# Altium schematic sheet MCU - MKL05VQFN24.SchDoc("MCU - MKL05VQFN24")
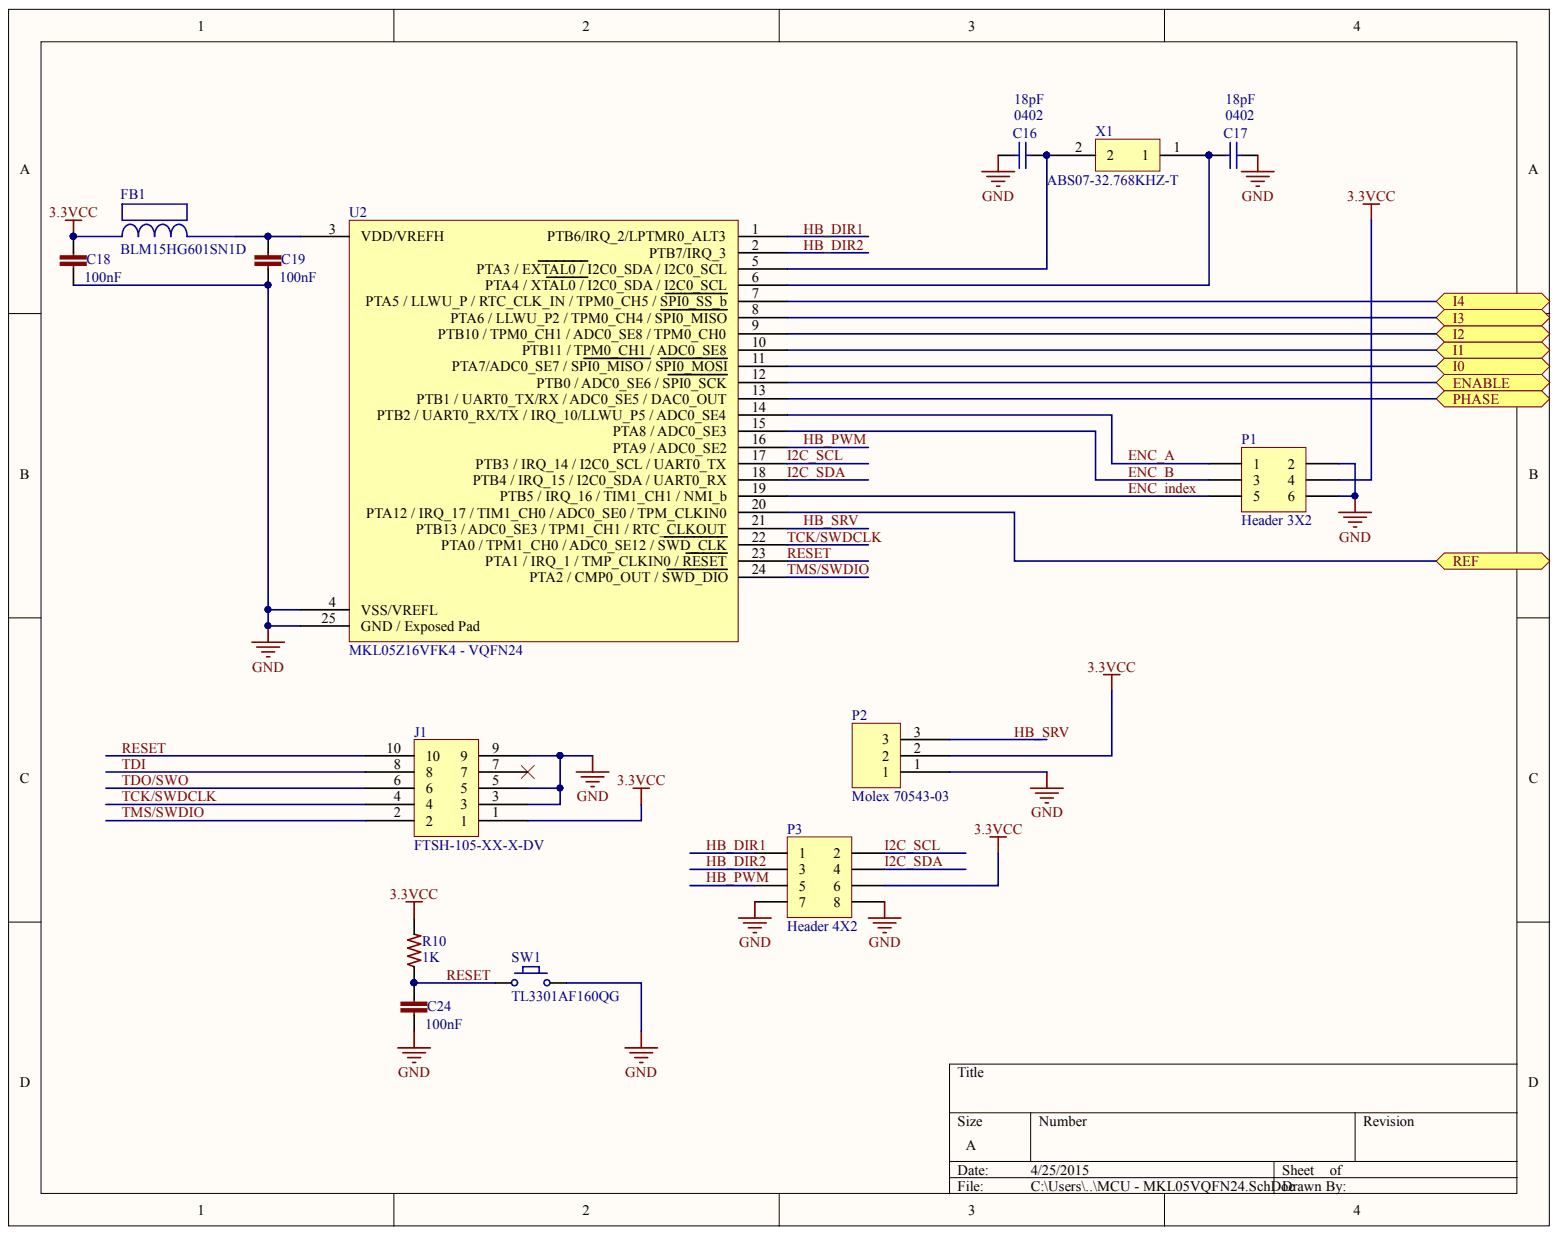
[diagram p0: MCU - MKL05VQFN24.SchDoc]

## Parts
C16  0402  value 18pF  [C0402]
C17  0402  value 18pF  [C0402]
C18  100nF  [C0402]
C19  100nF  [C0402]
C24  100nF  [C0402]
FB1  BLM15HG601SN1D  [BLM18PG121SN1D]
J1  FTSH-105-XX-X-DV  [FTSH-105-XX-X-DV]
P1  Header 3X2  [HDR2X3 Shrouded - Molex 0901301106]
P2  Molex 70543-03  [70543-03]
P3  Header 4X2  [HDR2X4 Shrouded]
R10  1K  [R0603]
SW1  TL3301AF160QG  [TL3301AF160QG]
U2  MKL05Z16VFK4 - VQFN24  [98ASA00474D]
X1  ABS07-32.768KHZ-T  [ABS07-32.768KHZ-T]

## Ports
ENABLE, I0, I1, I2, I3, I4, PHASE, REF

## Nets
3.3VCC: C18.1 FB1.1 J1.1 P1.4 P2.2 P3.6 R10.2
GND: C16.2 C17.1 C18.2 C19.2 C24.2 J1.3 J1.5 J1.9 P1.2 P1.6 P2.1 P3.7 P3.8 SW1.2 U2.4(VSS/VREFL) U2.25(GND_/_Exposed_Pad)
ENABLE: U2.12(PTB0_/_ADC0_SE6_/_SPI0_SCK) ; port ENABLE
ENC_A: P1.1 U2.14(PTB2_/_UART0_RX/TX_/_IRQ_10/LLWU_P5_/_ADC0_SE4)
ENC_B: P1.3 U2.15(PTA8_/_ADC0_SE3)
ENC_INDEX: P1.5 U2.19(PTB5_/_IRQ_16_/_TIM1_CH1_/_NMI_b)
HB_DIR1: P3.1 U2.1(PTB6/IRQ_2/LPTMR0_ALT3)
HB_DIR2: P3.3 U2.2(PTB7/IRQ_3)
HB_PWM: P3.5 U2.16(PTA9_/_ADC0_SE2)
HB_SRV: P2.3 U2.21(PTB13_/_ADC0_SE3_/_TPM1_CH1_/_RTC_CLKOUT)
I0: U2.11(PTA7/ADC0_SE7_/_SPI0_MISO_/_SPI0_MOSI) ; port I0
I1: U2.10(PTB11_/_TPM0_CH1_/_ADC0_SE8) ; port I1
I2: U2.9(PTB10_/_TPM0_CH1_/_ADC0_SE8_/_TPM0_CH0) ; port I2
I2C_SCL: P3.2 U2.17(PTB3_/_IRQ_14_/_I2C0_SCL_/_UART0_TX)
I2C_SDA: P3.4 U2.18(PTB4_/_IRQ_15_/_I2C0_SDA_/_UART0_RX)
I3: U2.8(PTA6_/_LLWU_P2_/_TPM0_CH4_/_SPI0_MISO) ; port I3
I4: U2.7(PTA5_/_LLWU_P_/_RTC_CLK_IN_/_TPM0_CH5_/_SPI0_SS_b) ; port I4
PHASE: U2.13(PTB1_/_UART0_TX/RX_/_ADC0_SE5_/_DAC0_OUT) ; port PHASE
REF: U2.20(PTA12_/_IRQ_17_/_TIM1_CH0_/_ADC0_SE0_/_TPM_CLKIN0) ; port REF
RESET: C24.1 J1.10 R10.1 SW1.1 U2.23(PTA1_/_IRQ_1_/_TMP_CLKIN0_/_RESET)
TCK/SWDCLK: J1.4 U2.22(PTA0_/_TPM1_CH0_/_ADC0_SE12_/_SWD_CLK)
TDI: J1.8
TDO/SWO: J1.6
TMS/SWDIO: J1.2 U2.24(PTA2_/_CMP0_OUT_/_SWD_DIO)
NetC16_1: C16.1 U2.5(PTA3_/_EXTAL0_/_I2C0_SDA_/_I2C0_SCL) X1.2
NetC17_2: C17.2 U2.6(PTA4_/_XTAL0_/_I2C0_SDA_/_I2C0_SCL) X1.1
NetC19_1: C19.1 FB1.2 U2.3(VDD/VREFH)

Not connected: J1 7

## Library data of the parts
C16 C17 = CL05C180GB5NNNC: CAP CER 18PF 50V 2% NP0 0402; Manufacturer: Samsung; Component Kind: Standard; Component Type: Chip Capacitor; Datasheet: http://www.samsungsem.com/kr/front/downloadcms.do?path=/kr/support/product-search/mlcc/__icsFiles/afieldfile/2014/11/05&fileName=S_CL05C180GB5NNNC.pdf; Height: 0.25 ± 0.15mm; LatestRevisionDate: 10 - Dec - 2014; LatestRevisionNote: Ultimachine Footprint Added; Library Name: Tigershark3D.SchLib; Manufacture Part #: CL05C180GB5NNNC; Mounting Type: SMT 0402; PackageReference: 0402; Part Description: CAP CER 18PF 50V 2% NP0 0402; Published: 10 - Dec - 2014; Signal Integrity: Cap Semi; Simulation: CAP; Tolerance: 2%; Voltage: 50V; Publisher: Ultimachine
C18 C19 C24 = GRM155R71C104KA88D: CAP CER 0402 100nF 16V 10% X7R; LastRevisionNote: ImportComponents; Component Kind: Standard; LastRevisionDate: 23-Apr-2014; Library Name: 3Delight.SCHLIB; ManufacturerPartNumber: GRM155R71C104KA88D; Published: 23-Apr-2014; Signal Integrity: Model Name; Simulation: Model Name; VALUE: 100nF; Publisher: UltiMachine
J1 = ARM Cortex JTAG-DEBUG Header: Component Kind: Standard; Library Name: Adams Altium Library.SchLib
P1 = Header 3X2: Header, 3-Pin, Dual row; Component Kind: Standard; LatestRevisionDate: 17-Jul-2002; LatestRevisionNote: Re-released for DXP Platform.; Library Name: Miscellaneous Connectors.IntLib; Signal Integrity: Connector; Publisher: Altium Limited
P2 = Molex Endstop: Component Kind: Standard; Library Name: RADMBo Schematic Library.SchLib
P3 = Header 4X2: Header, 4-Pin, Dual row; Component Kind: Standard; LatestRevisionDate: 17-Jul-2002; LatestRevisionNote: Re-released for DXP Platform.; Library Name: Miscellaneous Connectors.IntLib; Signal Integrity: Connector; Publisher: Altium Limited
R10 = ERJ-3EKF1001V: RES 0603 1K 100mW 1%; LastRevisionNote: ImportComponents; Component Kind: Standard; LastRevisionDate: 23-Apr-2014; Library Name: 3Delight.SCHLIB; ManufacturerPartNumber: ERJ-3EKF1001V; Published: 23-Apr-2014; Signal Integrity: Model Name; Simulation: Model Name; VALUE: 1K; Publisher: UltiMachine
U2 = MKL05Z16VFK4 - 24 Pin VQFN: ARM Cortex M0+; Component Kind: Standard; Library Name: Encoder Fin.SchLib
X1 = ABS07-32.768KHZ-T: ABS07-32.768KHZ-T RTC Crystal Oscillator; Component Kind: Standard; Library Name: Adams Altium Library.SchLib
FB1 = BLM15HG601SN1D: Component Kind: Standard; Description: BLM15HG601SN1D; Library Name: AHD Part Library.SchLib
SW1 = TL3301AF160QG: Component Kind: Standard; Description: TL3301AF160QG; MFR#: TL3301AF160QG; Type: SMD Momentary Tactile Switch; Library Name: MongoosMini.SchLib
